# Altium schematic sheet 4G通信板.SchDoc(4G通信板)
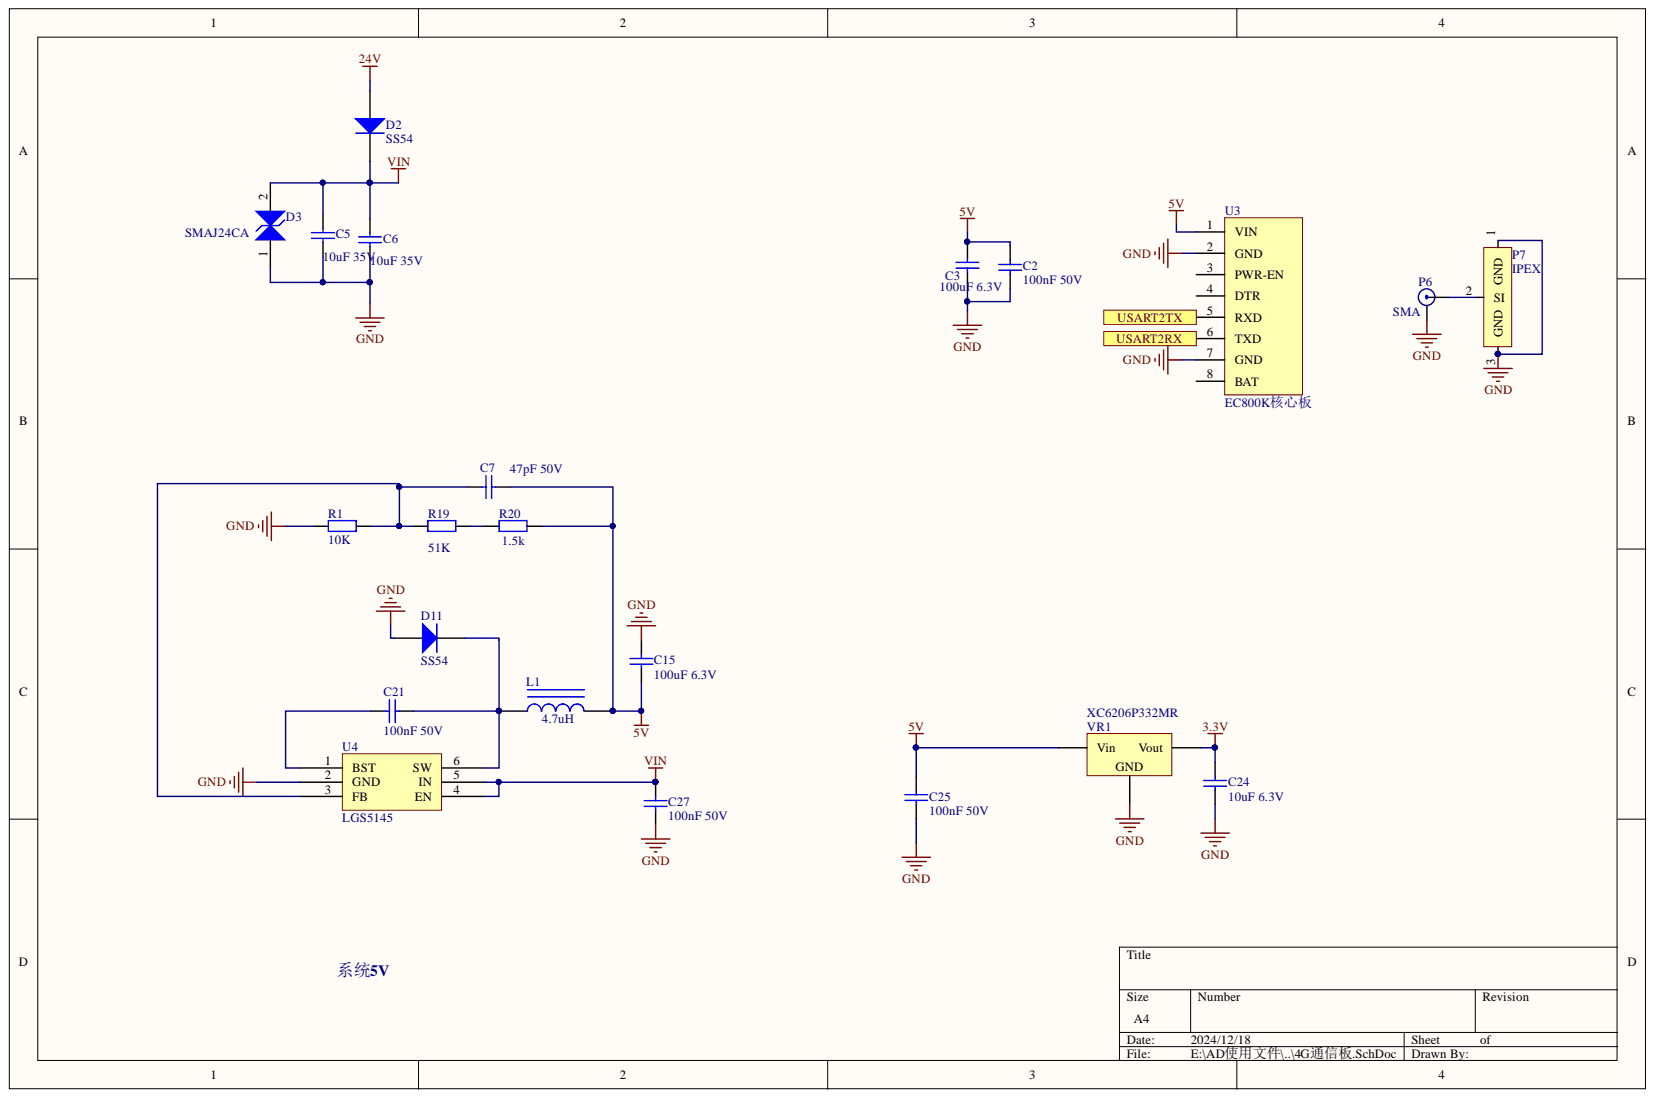
[diagram p0: 4G通信板.SchDoc]

## Parts
C2  100nF 50V  [0603_C]
C3  100uF 6.3V  [1206_C]
C5  10uF 35V  [0805_C]
C6  10uF 35V  [0805_C]
C7  47pF 50V  [0603_C]
C15  100uF 6.3V  [1206_C]
C21  100nF 50V  [0603_C]
C24  10uF 6.3V  [0603_C]
C25  100nF 50V  [0603_C]
C27  100nF 50V  [0603_C]
D2  SS54  [SMA(DO-214AC)_S1]
D3  SMAJ24CA  [SMA(DO-214AC)_S1]
D11  SS54  [SMA(DO-214AC)_S1]
L1  4.7uH  [RLF7030]
P6  SMA  [SMA]
P7  IPEX  [IPEX]
R1  10K  [0603_R]
R19  51K  [0603_R]
R20  1.5k  [0603_R]
U3  EC800K核心板  [EC800K核心板]
U4  LGS5145  [SOT-23-6]
VR1  XC6206P332MR  [SOT-23-3L]

## Ports
USART2RX, USART2TX

## Nets
3.3V: C24.2 VR1.2
5V: C2.2 C3.1 C7.2 C15.1 C25.2 L1.2 R20.2 U3.1(VIN) VR1.3
24V: D2.1
GND: C2.1 C3.2 C5.1 C6.1 C15.2 C24.1 C25.1 C27.1 D3.1 D11.1 P6.2 P7.1 P7.3 R1.1 U3.2(GND) U3.7(GND) U4.2(GND) VR1.1
VIN: C5.2 C6.2 C27.2 D2.2 D3.2 U4.4(EN) U4.5(IN)
USART2RX: U3.6(TXD) ; port USART2RX
USART2TX: U3.5(RXD) ; port USART2TX
NetC7_1: C7.1 R1.2 R19.1 U4.3(FB)
NetC21_1: C21.1 U4.1(BST)
NetC21_2: C21.2 D11.2 L1.1 U4.6(SW)
NetP6_1: P6.1 P7.2
NetR19_2: R19.2 R20.1

Not connected: U3 3(PWR-EN) 4(DTR) 8(BAT)

## Library data of the parts
C2 C25 C27 = 0402 100nF (104) 10% 16V: 贴片电容; SuppliersPartNumber: C1525; Supplier: LC; Package: 0402; Library Name: Cap.SchLib; ComponentLink1URL: http://www.szlcsc.com/product/details_1877.html; ComponentLink1Description: 供应商链接; Component Kind: Standard
C3 = Cap: Capacitor; Value: 1uF; Simulation: CAP; Published: 8-Jun-2000; PackageReference: RAD-0.3; PackageDescription: Radial Cap, Thru-Hole; 2 Leads; 0.3 in Pin Spacing; LatestRevisionNote: Re-released for DXP Platform.; LatestRevisionDate: 17-Jul-2002; Component Kind: Standard; Publisher: Altium Limited
C5 C6 C15 = 0805 22uF (226) 20% 25V: 贴片电容; SuppliersPartNumber: C45783; Supplier: LC; Package: 0805; Library Name: Cap.SchLib; ComponentLink1URL: http://www.szlcsc.com/product/details_46786.html; ComponentLink1Description: 供应商链接; Component Kind: Standard
C7 C21 = 0402 10nF (103) 10% 50V: 贴片电容; SuppliersPartNumber: C15195; Supplier: LC; Package: 0402; Library Name: Cap.SchLib; ComponentLink1URL: http://www.szlcsc.com/product/details_15869.html; ComponentLink1Description: 供应商链接; Component Kind: Standard
C24 = 0402 10uF (106) 20% 6.3V: 贴片电容; SuppliersPartNumber: C15525; Supplier: LC; Package: 0402; Library Name: Cap.SchLib; ComponentLink1URL: http://www.szlcsc.com/product/details_16204.html; ComponentLink1Description: 供应商链接; Component Kind: Standard
D2 D11 = SMA(DO-214AC) SS54: 二极管; SuppliersPartNumber: C22452; Supplier: LC; Package: SMA(DO-214AC); Library Name: Diode.SchLib; ComponentLink1URL: http://www.szlcsc.com/product/details_23178.html; ComponentLink1Description: 供应商链接; Component Kind: Standard
D3 = SMB/DO-214AA P6SMB6.8CA: TVS; SuppliersPartNumber: C78395; Supplier: LC; Package: SMB/DO-214AA; Library Name: Diode.SchLib; ComponentLink1URL: http://www.szlcsc.com/product/details_79530.html; ComponentLink1Description: 供应商链接; Component Kind: Standard
L1 = 1206 10uH 10%: 贴片电感; SuppliersPartNumber: C1051; Supplier: LC; Package: 1206; Library Name: IUD.SchLib; ComponentLink1URL: http://www.szlcsc.com/product/details_1403.html; ComponentLink1Description: 供应商链接; Component Kind: Standard
R1 = 0402 7.5KΩ (7501) 1%: 贴片电阻; SuppliersPartNumber: C25918; Supplier: LC; Package: 0402; Library Name: RES.SchLib; ComponentLink1URL: http://www.szlcsc.com/product/details_26661.html; ComponentLink1Description: 供应商链接; Component Kind: Standard
R19 = 0402 49.9KΩ (4992) 1%: 贴片电阻; SuppliersPartNumber: C25897; Supplier: LC; Package: 0402; Library Name: RES.SchLib; ComponentLink1URL: http://www.szlcsc.com/product/details_26640.html; ComponentLink1Description: 供应商链接; Component Kind: Standard
R20 = 0402 300Ω (3000) 1%: 贴片电阻; SuppliersPartNumber: C25102; Supplier: LC; Package: 0402; Library Name: RES.SchLib; ComponentLink1URL: http://www.szlcsc.com/product/details_25845.html; ComponentLink1Description: 供应商链接; Component Kind: Standard
U4 = MP2359DJ-LF-Z_[C14259]: DC-DC芯片; SuppliersPartNumber: C14259; Supplier: LC; Package: SOT-23-6; Library Name: IC.SchLib; ComponentLink1URL: http://www.szlcsc.com/product/details_14917.html; ComponentLink1Description: 供应商链接; Component Kind: Standard
VR1 = SOT-23 XC6206P332MR: 低压差线性稳压(LDO); SuppliersPartNumber: C5446; Supplier: LC; Package: SOT-23; Library Name: LDO.SchLib; ComponentLink1URL: http://www.szlcsc.com/product/details_5896.html; ComponentLink1Description: 供应商链接; Component Kind: Standard
P6 = SMA: Component Kind: Standard; Library Name: wgh元件库.SCHLIB
P7 = IPEX: Component Kind: Standard; Library Name: wgh元件库.SCHLIB
U3 = EC800K核心板: Component Kind: Standard; Library Name: LDGlib.SchLib
